# Altium schematic sheet signals.SchDoc
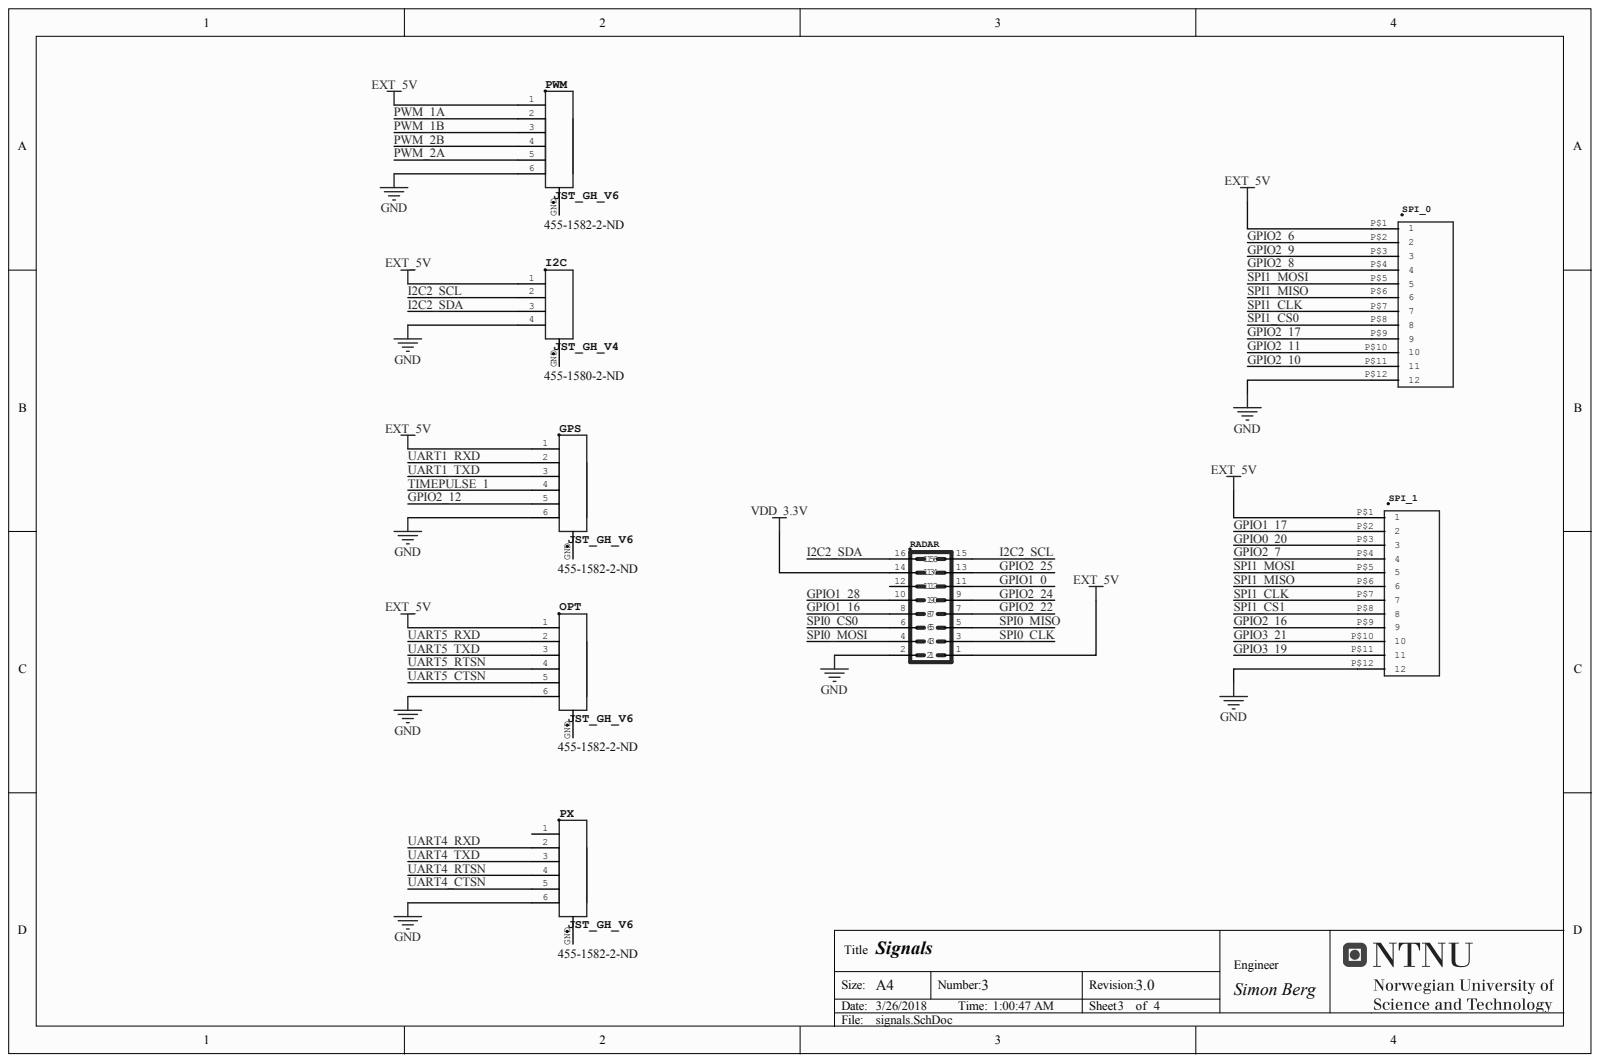
[diagram p0: signals.SchDoc]

## Parts
GPS  JST_GH_V6  Supplier Part Number 1: 455-1582-2-ND  [JST_GH_V6]
I2C  JST_GH_V4  Supplier Part Number 1: 455-1580-2-ND  [JST_GH_V4]
OPT  JST_GH_V6  Supplier Part Number 1: 455-1582-2-ND  [JST_GH_V6]
PWM  JST_GH_V6  Supplier Part Number 1: 455-1582-2-ND  [JST_GH_V6]
PX  JST_GH_V6  Supplier Part Number 1: 455-1582-2-ND  [JST_GH_V6]
RADAR  Pin Header Box-Type, 1.27mm/0.5"/50mil Pitch, 2x08 Circuits   Note: see library description for an important note about THT-version  [PHB_1MM27_2X08_SNT]  (value not drawn)
SPI_0  JST_GH_12P_SIDEH  [JST_GH_12P_SIDE]  (value not drawn)
SPI_1  JST_GH_12P_SIDEH  [JST_GH_12P_SIDE]  (value not drawn)

## Nets
EXT_5V: GPS.1 I2C.1 OPT.1 PWM.1 RADAR.1 SPI_0.P$1 SPI_1.P$1
GND: GPS.6 I2C.4 OPT.6 PWM.6 PX.6 RADAR.2 SPI_0.P$12 SPI_1.P$12
VDD_3.3V: RADAR.14
GPIO0_20: SPI_1.P$3
GPIO1_0: RADAR.11
GPIO1_16: RADAR.8
GPIO1_17: SPI_1.P$2
GPIO1_28: RADAR.10
GPIO2_6: SPI_0.P$2
GPIO2_7: SPI_1.P$4
GPIO2_8: SPI_0.P$4
GPIO2_9: SPI_0.P$3
GPIO2_10: SPI_0.P$11
GPIO2_11: SPI_0.P$10
GPIO2_12: GPS.5
GPIO2_16: SPI_1.P$9
GPIO2_17: SPI_0.P$9
GPIO2_22: RADAR.7
GPIO2_24: RADAR.9
GPIO2_25: RADAR.13
GPIO3_19: SPI_1.P$11
GPIO3_21: SPI_1.P$10
I2C2_SCL: I2C.2 RADAR.15
I2C2_SDA: I2C.3 RADAR.16
PWM_1A: PWM.2
PWM_1B: PWM.3
PWM_2A: PWM.5
PWM_2B: PWM.4
SPI0_CLK: RADAR.3
SPI0_CS0: RADAR.6
SPI0_MISO: RADAR.5
SPI0_MOSI: RADAR.4
SPI1_CLK: SPI_0.P$7 SPI_1.P$7
SPI1_CS0: SPI_0.P$8
SPI1_CS1: SPI_1.P$8
SPI1_MISO: SPI_0.P$6 SPI_1.P$6
SPI1_MOSI: SPI_0.P$5 SPI_1.P$5
TIMEPULSE_1: GPS.4
UART1_RXD: GPS.2
UART1_TXD: GPS.3
UART4_CTSN: PX.5
UART4_RTSN: PX.4
UART4_RXD: PX.2
UART4_TXD: PX.3
UART5_CTSN: OPT.5
UART5_RTSN: OPT.4
UART5_RXD: OPT.2
UART5_TXD: OPT.3

Not connected: GPS GND; I2C GND; OPT GND; PWM GND; PX 1 GND; RADAR 12

## Library data of the parts
GPS OPT PWM PX = JST_GH_V6: BM06B-GHS-TBT; Component Kind: Standard; Supplier 1: Digi-Key; Library Name: cb_untouched.SCHLIB
I2C = JST_GH_V4: BM04B-GHS-TBT; Component Kind: Standard; Supplier 1: Digi-Key; Library Name: cb_untouched.SCHLIB
RADAR = HEADER_2X08_1.27MMSNT: DeviceName: SNT; GateName_1: G$1; SymbolName_1: 2X08M; Component Kind: Standard; DeviceSetName: HEADER_2X08_1.27MM; Library Name: autowing_lib.SCHLIB; LibraryName: autowing_lib
SPI_0 SPI_1 = JST_GH_12P_SIDEH: DeviceName: H; GateName_1: G$1; Component Kind: Standard; DeviceSetName: JST_GH_12P_SIDE; SymbolName_1: JST_GH_12P; Library Name: autowing_connector.SCHLIB; LibraryName: autowing_connector
